# Altium schematic sheet SwitchingRegulators.SchDoc(SwitchingRegulators)
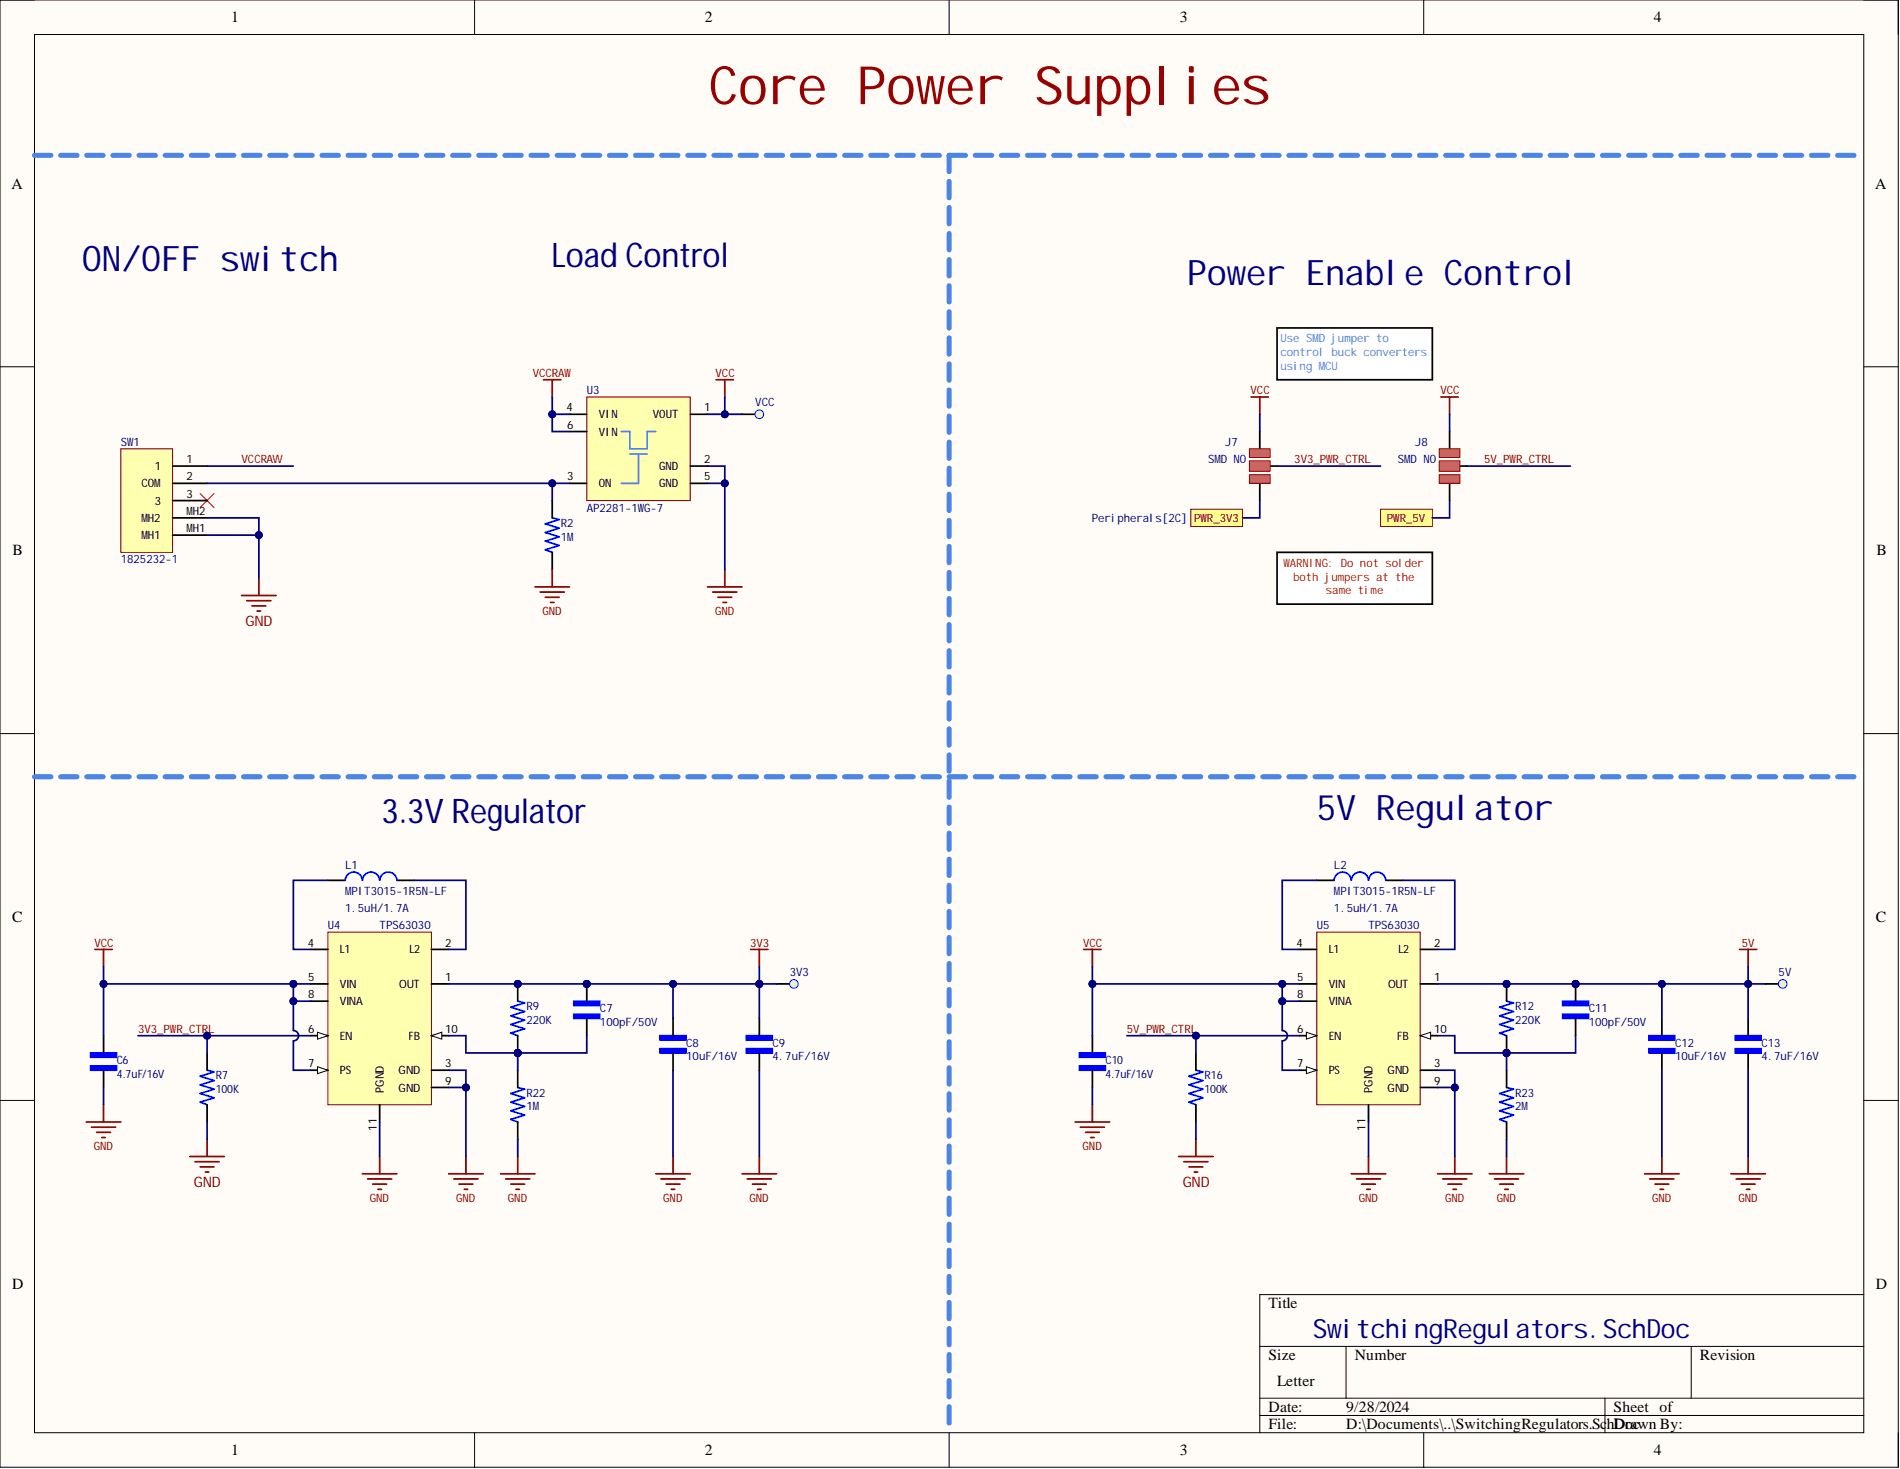
[diagram p0: SwitchingRegulators.SchDoc]

## Parts
3V3  Test point 1.2mm  [TEST_POINT_1.2MM]  (value not drawn)  (no BOM)
5V  Test point 1.2mm  [TEST_POINT_1.2MM]  (value not drawn)  (no BOM)
C6  4.7uF/16V  [C0603]
C7  100pF/50V  [C0603]
C8  10uF/16V  [C0603]
C9  4.7uF/16V  [C0603]
C10  4.7uF/16V  [C0603]
C11  100pF/50V  [C0603]
C12  10uF/16V  [C0603]
C13  4.7uF/16V  [C0603]
J7  SMD NO  [JUMPER_SMD_NO_2WAY]
J8  SMD NO  [JUMPER_SMD_NO_2WAY]
L1  1.5uH/1.7A  [L3030_SHIELD_H15]
L2  1.5uH/1.7A  [L3030_SHIELD_H15]
R2  1M  [R0603]
R7  100K  [R0603]
R9  220K  [R0603]
R12  220K  [R0603]
R16  100K  [R0603]
R22  1M  [R0603]
R23  2M  [R0603]
SW1  1825232-1  [1825232-1]
U3  AP2281-1WG-7  [SOT-26-6]
U4  TPS63030  [WSON-N10]
U5  TPS63030  [WSON-N10]
VCC  Test point 1.2mm  [TEST_POINT_1.2MM]  (value not drawn)  (no BOM)

## Ports
PWR_3V3, PWR_5V

## Nets
3V3: 3V3.1 C7.1 C8.1 C9.1 R9.2 U4.1(OUT)
5V: 5V.1 C11.1 C12.1 C13.1 R12.2 U5.1(OUT)
GND: C6.2 C8.2 C9.2 C10.2 C12.2 C13.2 R2.1 R7.1 R16.1 R22.1 R23.1 SW1.MH1 SW1.MH2 U3.2(GND) U3.5(GND) U4.3(GND) U4.9(GND) U4.11(PGND) U5.3(GND) U5.9(GND) U5.11(PGND)
VCC: C6.1 C10.1 J7.3 J8.3 U3.1(VOUT) U4.5(VIN) U4.7(PS) U4.8(VINA) U5.5(VIN) U5.7(PS) U5.8(VINA) VCC.1
3V3_PWR_CTRL: J7.2 R7.2 U4.6(EN)
5V_PWR_CTRL: J8.2 R16.2 U5.6(EN)
PWR_3V3: J7.1 ; port PWR_3V3
PWR_5V: J8.1 ; port PWR_5V
VCCRAW: SW1.1 U3.4(VIN) U3.6(VIN)
NetC7_2: C7.2 R9.1 R22.2 U4.10(FB)
NetC11_2: C11.2 R12.1 R23.2 U5.10(FB)
NetL1_1: L1.1 U4.4(L1)
NetL1_2: L1.2 U4.2(L2)
NetL2_1: L2.1 U5.4(L1)
NetL2_2: L2.2 U5.2(L2)
NetR2_2: R2.2 SW1.2(COM) U3.3(ON)

Not connected: SW1 3

## Library data of the parts
3V3 5V VCC = Test point: SMD test point; Library Name: Connectors.SchLib
C6 C9 C10 C13 = C0603 4.7uF/16V: MLCC 0603 4.7uF 16V 10% X5R; Manufacturer 1: Murata; Manufacturer Part Number 1: GRT188R61C475KE13D; Case Code (Imperial): 0603; Case Code (Metric): 1608; Case/Package: 0603; Category: Ceramic Capacitors; Component Kind: Standard; Length: 0.063inch; Library Name: Capacitors.SchLib; Max Operating Temperature: 85°C; Min Operating Temperature: -55°C; Mounting Technology: Surface Mount; Packaging: Cut Tape; Pricing 1: 1=0.16, 10=0.066, 50=0.066, 100=0.038, 1000=0.034, 10000=0.029 (USD); Ratings: AEC-Q200; RoHS: Yes; RoHS Compliant: Yes; Series: GRT; Stock 1: 39950; Supplier 1: Mouser; Supplier Part Number 1: 81-GRT188R61C475KE3D; Thickness: 0.035inch; Tolerance: 10%; Value: 4.7uF; Voltage Rating: 16V; Width: 0.031inch
C7 C11 = C0603 100pF/50V: AVX - 06035A101JAT2A - SMD Multilayer Ceramic Capacitor, 100 pF, 5%, C0G / NP0, 50 V, 0603 [1608 Metric] RoHS Compliant: Yes; Manufacturer: Kyocera AVX; Capacitance: 100pF; Insulation Resistance: 100GR; Temperature Coefficient: 30ppm/°C; Voltage: 50V; Case Code (Imperial): 0603; Case Code (Metric): 1608; Case/Package: 0603; Category: Ceramic Capacitors; Component Kind: Standard; Depth: 0.81mm; Dielectric: C0G; Height: 0.9mm; Lead Free: Lead Free; Length: 1.6mm; Library Name: Capacitors.SchLib; Manufacturer Part Number: 06035A101JAT2A; Max Operating Temperature: 125°C; Min Operating Temperature: -55°C; Mount: Surface Mount,PCB; Number of Pins: 2; Package Quantity: 1; Packaging: Tape and Reel; Pricing 1: 1=0.10000, 10=0.04100, 50=0.04100, 100=0.03300, 1000=0.01900, 10000=0.01200 (USD); Radiation Hardening: No; REACH SVHC: No SVHC; RoHS: Yes; RoHS Compliant: Yes; Stock 1: 438925; Supplier 1: Mouser; Supplier 2: Mouser; Supplier Part Number 1: 581-06035A101J; Supplier Part Number 2: 77-VJ0603A101JXAAC; Termination: SMD/SMT; Thickness: 0.035inch; Tolerance: 5%; Voltage Rating: 50V; Voltage Rating (DC): 50V; Width: 0.032inch
C8 C12 = C0603 10uF/16V: MLCC 0603 10uF 16V 20% X5R; Manufacturer 1: Murata; Manufacturer Part Number 1: GRM188R61C106MAALD; Material: Ceramic; Capacitance: 10uF; Case Code (Imperial): 0603; Case Code (Metric): 1608; Case/Package: 0603; Category: Ceramic Capacitors; Component Kind: Standard; Height: 0.8mm; Lead Free: Lead Free; Length: 0.063inch; Library Name: Capacitors.SchLib; Max Operating Temperature: 85°C; Min Operating Temperature: -55°C; Mount: Surface Mount; Packaging: Cut Tape; Pricing 1: 1=0.38000, 10=0.27100, 50=0.27100, 100=0.16200, 1000=0.10300, 10000=0.08800 (USD); RoHS: Yes; Stock 1: 9439; Supplier 1: Mouser; Supplier 2: Mouser; Supplier 3: Mouser; Supplier Part Number 1: 81-GRM188R61C106MALD; Supplier Part Number 2: 81-ZRB18AR61C106ME1L; Supplier Part Number 3: 810-C1608X5R1C106M; Thickness: 0.037inch; Tolerance: 20%; Voltage Rating: 16V; Voltage Rating (DC): 16V; Width: 0.031inch
J7 J8 = JUMPER_SMD_NO_2WAY: Component Kind: Net Tie; Library Name: Jumpers.SchLib
L1 L2 = MPIT3015-1R5N-LF: Inductor Power Shielded Wirewound 1.5uH 20% 152MHz Metal 2.3A 0.05Ohm DCR 1212 T/R; Manufacturer 1: microgate; Category: Fixed Inductors; Component Kind: Standard; Library Name: Inductors.SchLib; Manufacturer Part Number 1: MPIT3015-1R5N-LF; RoHS: Yes; Supplier 1: LCSC; Supplier Part Number 1: C486478
R2 = R0603 1M: RES SMD 1M OHM 1% 1/10W 0603; Manufacturer: Yageo; Manufacturer Part Number: RC0603FR-071ML; Application(s): HIGH RELIABILITY; Power Rating: 100mW; Resistance: 1MR; Temperature Coefficient: 100ppm/°C; Voltage Rating: 75V; Voltage Rating (DC): 50V; Case Code (Imperial): 0603; Case Code (Metric): 1608; Case/Package: 0603; Category: Chip SMD Resistors; Component Kind: Standard; Depth: 0.8mm; Height: 0.45mm; Lead Free: Lead Free; Length: 1.6mm; Library Name: Resistors.SchLib; Max Operating Temperature: 155°C; Military Standard: Not; Min Operating Temperature: -55°C; Number of Pins: 2; Package Quantity: 5000; Packaging: Cut Tape; Pricing 1: 1=0.10000, 10=0.01800, 50=0.01800, 100=0.00700, 1000=0.00400, 10000=0.00200 (USD); REACH SVHC: No SVHC; Resistor Type: Thick Film; RoHS: Yes; RoHS Compliant: Yes; Series: RC; Stock 1: 1321822; Supplier 1: Mouser; Supplier Part Number 1: 603-RC0603FR-071ML; Technology: THICK FILM; Termination: SMD/SMT; Tolerance: 1%; Type: General Purpose; Weight: 0.000847oz; Width: 0.8mm
R7 R16 = R0603 100K: RES 0603 100K OHM 1% 1/10W; Manufacturer: Yageo; Manufacturer Part Number: RC0603FR-07100KL; Power Rating: 100mW; Resistance: 100kR; Temperature Coefficient: 100ppm/°C; Voltage Rating: 50V; Working Voltage: 75V; Case Code (Imperial): 0603; Case Code (Metric): 1608; Case/Package: 0603; Category: Chip SMD Resistors; Component Kind: Standard; Depth: 0.8mm; Height: 0.45mm; Lead Free: Lead Free; Length: 1.6mm; Library Name: Resistors.SchLib; Max Operating Temperature: 155°C; Military Standard: Not; Min Operating Temperature: -55°C; Number of Pins: 2; Number of Terminations: 2; Packaging: Tape and Reel; Pricing 1: 1=0.10000, 10=0.02100, 100=0.00900, 1000=0.00400, 5000=0.00300, 10000=0.00200 (USD); REACH SVHC: No SVHC; Resistor Type: Thick Film; RoHS: Yes; Stock 1: 4536807; Supplier 1: Mouser; Supplier Part Number 1: 603-RC0603FR-07100KL; Termination: SMD/SMT; Tolerance: 1%; Voltage Rating (DC): 50V; Width: 0.8mm
R9 R12 = R0603 220K: RES 0603 220K OHM 1% 1/10W; Manufacturer 1: Yageo; Manufacturer Part Number 1: RC0603FR-07220KL; Max Power Dissipation: 100mW; Resistance: 220kR; Temperature Coefficient: 100ppm/°C; Voltage Rating: 50V; Case Code (Imperial): 0603; Case Code (Metric): 1608; Case/Package: 0603; Category: Chip SMD Resistors; Component Kind: Standard; Depth: 0.8mm; Features: Moisture Resistant; Height: 0.022inch; Lead Free: Lead Free; Length: 0.063inch; Library Name: Resistors.SchLib; Max Operating Temperature: 155°C; Min Operating Temperature: -55°C; Number of Pins: 2; Number of Terminations: 2; Package Quantity: 1; Packaging: Tape and Reel; Power Rating: 100mW; Pricing 1: 1=0.10000, 10=0.02400, 50=0.02400, 100=0.01000, 1000=0.00400, 10000=0.00300 (USD); REACH SVHC: No SVHC; Resistance Tolerance: 1%; Resistor Type: Thick Film; RoHS: Yes; RoHS Compliant: Yes; Series: RC; Size Code: 0603; Stock 1: 155701; Supplier 1: Mouser; Supplier Part Number 1: 603-RC0603FR-07220KL; Technology: THICK FILM; Termination: SMD/SMT; Tolerance: 1%; Voltage Rating (DC): 50V; Width: 0.031inch
R22 = R0603 1M: RES 0603 1M OHM 1% 1/10W; Manufacturer 1: Yageo; Manufacturer Part Number 1: RC0603FR-071ML; Application(s): HIGH RELIABILITY; Power Rating: 100mW; Resistance: 1MR; Temperature Coefficient: 100ppm/°C; Voltage Rating: 75V; Voltage Rating (DC): 50V; Case Code (Imperial): 0603; Case Code (Metric): 1608; Case/Package: 0603; Category: Chip SMD Resistors; Component Kind: Standard; Depth: 0.8mm; Height: 0.45mm; Lead Free: Lead Free; Length: 1.6mm; Library Name: Resistors.SchLib; Max Operating Temperature: 155°C; Military Standard: Not; Min Operating Temperature: -55°C; Number of Pins: 2; Package Quantity: 5000; Packaging: Cut Tape; Pricing 1: 1=0.10000, 10=0.01800, 50=0.01800, 100=0.00700, 1000=0.00400, 10000=0.00200 (USD); REACH SVHC: No SVHC; Resistor Type: Thick Film; RoHS: Yes; RoHS Compliant: Yes; Series: RC; Stock 1: 1321822; Supplier 1: Mouser; Supplier Part Number 1: 603-RC0603FR-071ML; Technology: THICK FILM; Termination: SMD/SMT; Tolerance: 1%; Type: General Purpose; Weight: 0.000847oz; Width: 0.8mm
R23 = R0603 2M: RES 0603 2M OHM 1% 1/10W; Manufacturer 1: Vishay; Manufacturer Part Number 1: CRCW06032M00FKEAC; Category: Chip SMD Resistors; Component Kind: Standard; Library Name: Resistors.SchLib; Pricing 1: 1=0.10000, 10=0.04300, 50=0.04300, 100=0.01800, 1000=0.00900, 10000=0.00500 (USD); RoHS: Yes; RoHS Compliant: Yes; Stock 1: 40195; Supplier 1: Mouser; Supplier 2: Digi-Key; Supplier Part Number 1: 71-CRCW06032M00FKEAC; Supplier Part Number 2: 541-5333-1-ND
SW1 = 1825232-1: Slide Switch, SPDT, On-on, Latched, 0.2A, 30VDC, Solder Terminal, Panel Mount; Manufacturer: TE Connectivity AMP; Actuator Length: 0.118inch; Actuator Material: Nylon; Actuator Type: Standard; Contact Current Rating: 200mA; Electrical Life: 10000Cycles; Max Voltage Rating (DC): 30V; Number of Positions: 2; Operating Force: 200gf; PCB Mount Retention: With; Switch Travel: 2mm; Tail Length: 0.094inch; Category: Slide Switches; Component Kind: Standard; ComponentLink1Description: https://datasheet.ciiva.com/15085/eng-cd-1825232-b2-15085854.pdf?src-supplier=Digi-Key; ComponentLink1URL: https://datasheet.ciiva.com/15085/eng-cd-1825232-b2-15085854.pdf?src-supplier=Digi-Key; Contact Material: Copper Alloy; Contact Plating: Silver; Current Rating: 200mA; ELV: Compliant; Flammability Rating: UL94 HB; Length: 0.3563inch; Library Name: Switches.SchLib; Manufacturer Part Number: 1825232-1; Max Operating Temperature: 70°C; Mechanical Life: 10000Cycles; Min Operating Temperature: -20°C; Mounting Technology: Through Hole; Orientation: Right Angle; Package Quantity: 19200; Packaging: Tray; Pricing 1: 1=0.4, 10=0.382, 50=0.361, 100=0.321, 1000=0.247, 10000=0.24 (USD); RoHS: Yes; RoHS Compliant: Yes; Stock 1: 12457; Supplier 1: Mouser; Supplier 2: Digi-Key; Supplier Part Number 1: 655-1825232-1; Supplier Part Number 2: A107673-ND; Switch Function: On-On; Termination: PC Pin; Throw Configuration: SPDT; Type: Switch; Voltage Rating (DC): 30V; Width: 4.3mm
U3 = AP2281-1WG-7: Load Switch P-Channel 2A SOT-26-6; Manufacturer: Diodes; Interface: On/Off; Max Power Dissipation: 720mW; Max Supply Voltage: 6V; Min Supply Voltage: 1.5V; Number of Outputs: 1; On-State Resistance: 210mR; On-state Resistance-Max: 100MR; Output Configuration: High Side; Output Current: 0A; Case/Package: SOT-26; Category: Hot Swap Controllers; Component Kind: Standard; Current Rating: 2A; Lead Free: Lead Free; Library Name: IC Power.SchLib; Manufacturer Part Number: AP2281-1WG-7; Max Operating Temperature: 85°C; Max Output Current: 2A; Min Operating Temperature: -40°C; Mount: Surface Mount; Number of Pins: 6; Package Quantity: 3000; Packaging: Tape and Reel; Power Dissipation: 720mW; Pricing 1: 1=0.66000, 10=0.57900, 50=0.57900, 100=0.44400, 250=0.41200, 500=0.35100, 1000=0.28100, 3000=0.25400, 6000=0.23900, 9000=0.23700, 10000=0.23700 (USD); Radiation Hardening: No; Rated Current-Max: 2A; REACH SVHC: No SVHC; RoHS: Yes; RoHS Compliant: Yes; Series: -; Stock 1: 4712; Supplier 1: Mouser; Supplier 2: LCSC; Supplier Part Number 1: 621-AP2281-1WG-7; Supplier Part Number 2: C127279
U4 U5 = TPS63030DSKR: Buck-Boost Switching Regulator IC Positive Adjustable 1.2V 1 Output 900mA (Switch) 10-WFDFN Exposed Pad; Manufacturer 1: Texas Instruments; Efficiency: 96%; Max Output current: 800mA; Max Output Voltage: 5.5V; Min Input Voltage: 1.8V; Number of Outputs: 1; Quiescent Current: 25uA; Supply Current: 50uA; Switching Frequency: 2.4MHz; Synchronous Rectifier: Yes; Case/Package: SON; Category: Voltage Regulators - Switching; Component Kind: Standard; Lead Free: Lead Free; Library Name: IC Power.SchLib; Manufacturer Part Number 1: TPS63030DSKR; Max Operating Temperature: 85°C; Max Supply Voltage: 5.5V; Min Operating Temperature: -40°C; Min Output Voltage: 1.2V; Min Supply Voltage: 1.8V; Mounting Technology: Surface Mount; Operating Supply Voltage: 5.5V; Output Configuration: Positive; Output Current: 800mA; Output Type: Adjustable; Output Voltage: 5.5V; Package Quantity: 3000; Packaging: Tape and Reel; Pins: 10; Pricing: 1=1.91, 10=1.64, 50=1.64, 100=1.41, 1000=1.01, 10000=0.886 (USD); Radiation Hardening: No; Reach SVHC Compliant: No; RoHS: Yes; RoHS Compliant: Yes; Series: -; Stock: 10596; Supplier 1: Mouser; Supplier 2: LCSC; Supplier Part Number 1: 595-TPS63030DSKR; Supplier Part Number 2: C12462; Topology: Buck-Boost
